# Altium schematic sheet REO_ECG.SchDoc
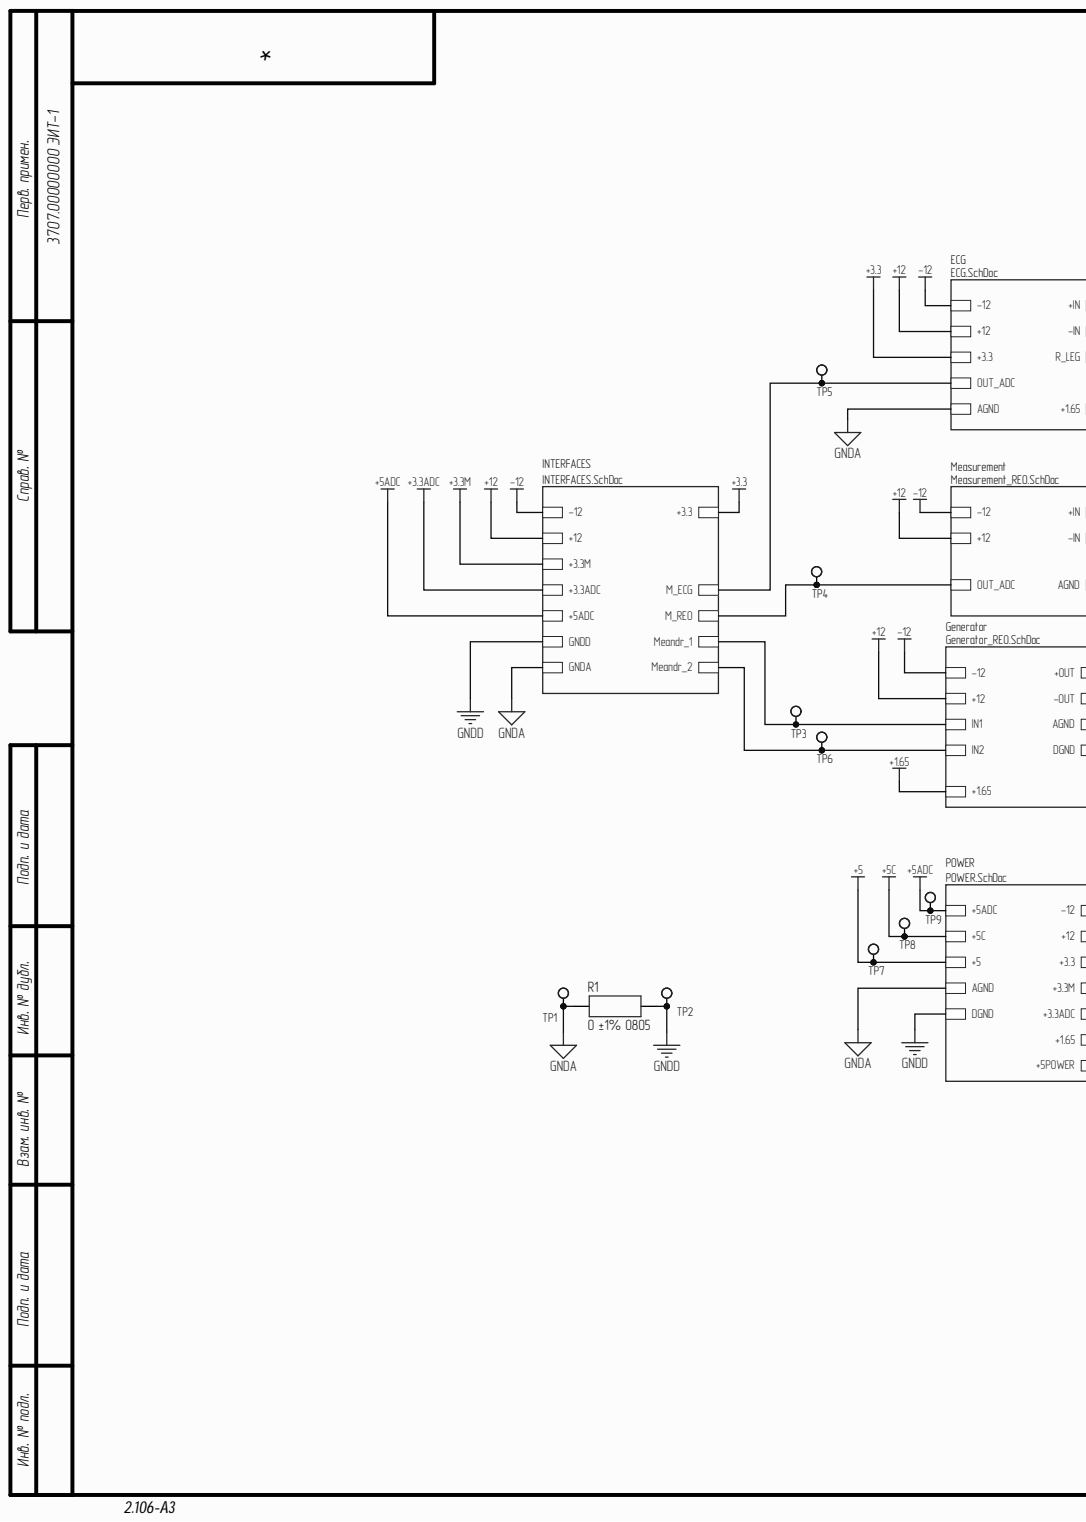
[diagram p0: REO_ECG.SchDoc, left]
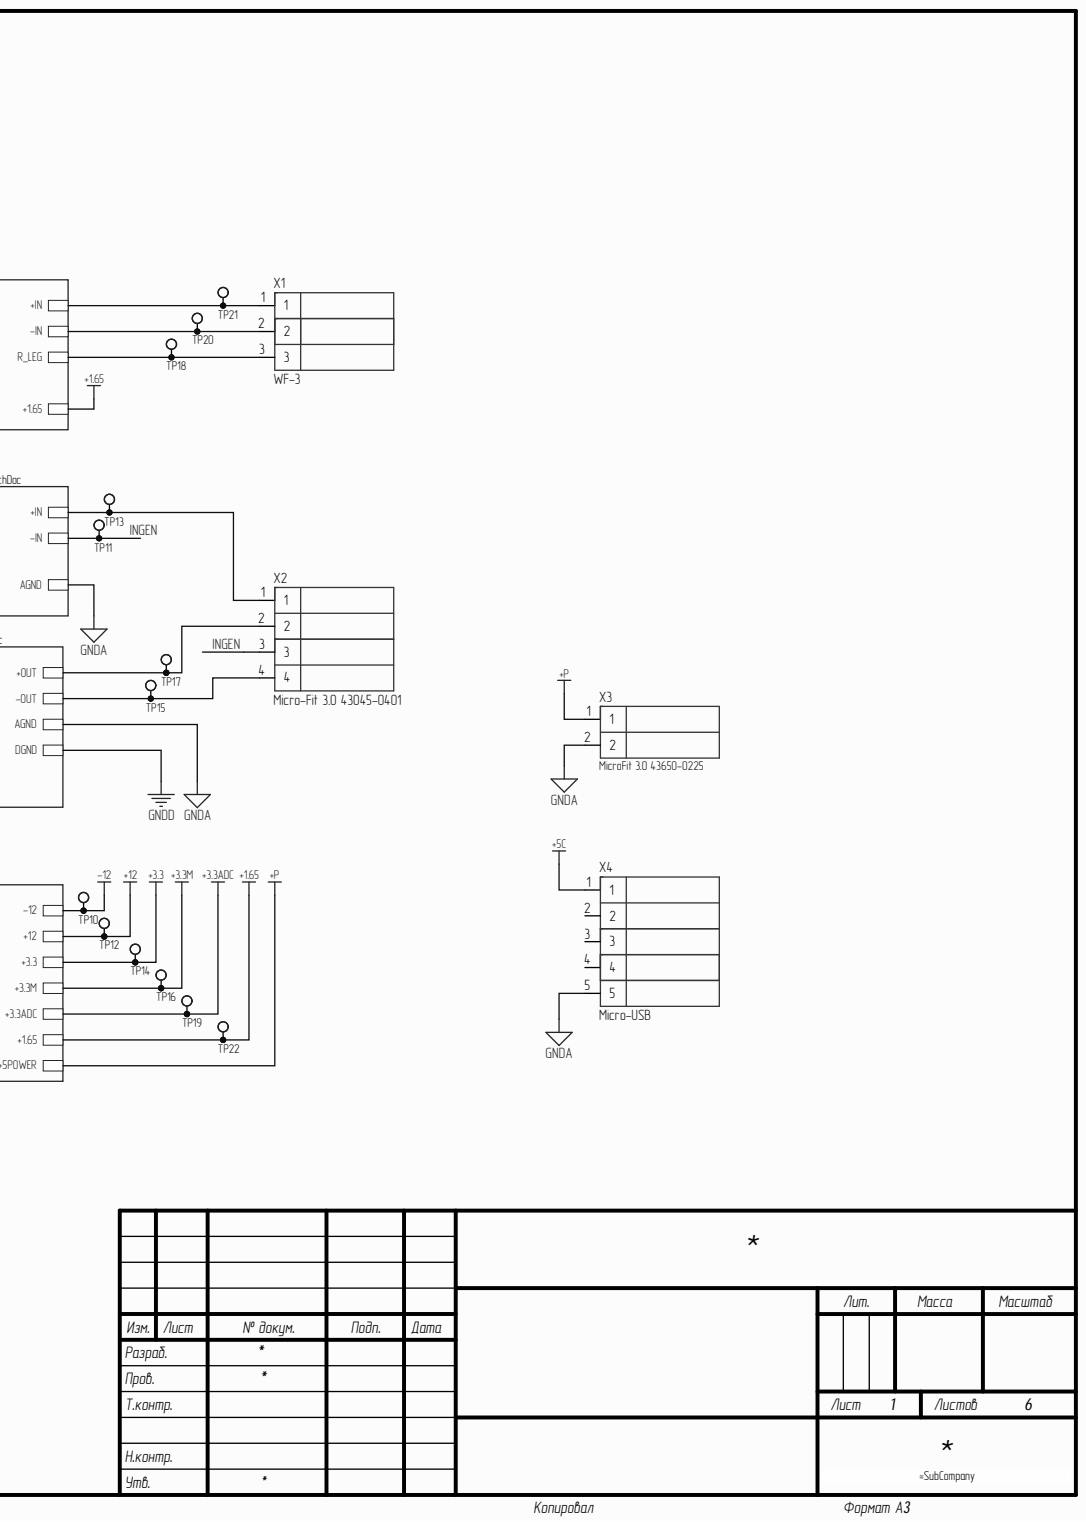
[diagram p1: REO_ECG.SchDoc, right]

## Parts
R1  0 ±1% 0805  [RES: SMD 0805 MD IPC 782]
TP1  Test point  [Test Point]  (value not drawn)  (net tie)
TP2  Test point  [Test Point]  (value not drawn)  (net tie)
TP3  Test point  [Test Point]  (value not drawn)  (net tie)
TP4  Test point  [Test Point]  (value not drawn)  (net tie)
TP5  Test point  [Test Point]  (value not drawn)  (net tie)
TP6  Test point  [Test Point]  (value not drawn)  (net tie)
TP7  Test point  [Test Point]  (value not drawn)  (net tie)
TP8  Test point  [Test Point]  (value not drawn)  (net tie)
TP9  Test point  [Test Point]  (value not drawn)  (net tie)
TP10  Test point  [Test Point]  (value not drawn)  (net tie)
TP11  Test point  [Test Point]  (value not drawn)  (net tie)
TP12  Test point  [Test Point]  (value not drawn)  (net tie)
TP13  Test point  [Test Point]  (value not drawn)  (net tie)
TP14  Test point  [Test Point]  (value not drawn)  (net tie)
TP15  Test point  [Test Point]  (value not drawn)  (net tie)
TP16  Test point  [Test Point]  (value not drawn)  (net tie)
TP17  Test point  [Test Point]  (value not drawn)  (net tie)
TP18  Test point  [Test Point]  (value not drawn)  (net tie)
TP19  Test point  [Test Point]  (value not drawn)  (net tie)
TP20  Test point  [Test Point]  (value not drawn)  (net tie)
TP21  Test point  [Test Point]  (value not drawn)  (net tie)
TP22  Test point  [Test Point]  (value not drawn)  (net tie)
X1  WF-3  [CON: 3pin wf-3]
X2  Micro-Fit 3.0 43045-0401  [CON: 4pin Molex MicroFit 3.0 43045-0401]
X3  MicroFit 3.0 43650-0225  [CON: 2pin Molex MicroFit 3.0 43650-0225]
X4  Micro-USB  [CON: 5pin USB Micro]

## Nets
+1.65: TP22.1
+3.3: TP14.1
+3.3ADC: TP19.1
+3.3M: TP16.1
+5: TP7.1
+5ADC: TP9.1
+5C: TP8.1 X4.1
+12: TP12.1
+P: X3.1
-12: TP10.1
GNDA: R1.1 TP1.1 X3.2 X4.5
GNDD: R1.2 TP2.1
INGEN: TP11.1 X2.3
NetTP3_1: TP3.1
NetTP4_1: TP4.1
NetTP5_1: TP5.1
NetTP6_1: TP6.1
NetTP13_1: TP13.1 X2.1
NetTP15_1: TP15.1 X2.4
NetTP17_1: TP17.1 X2.2
NetTP18_1: TP18.1 X1.3
NetTP20_1: TP20.1 X1.2
NetTP21_1: TP21.1 X1.1
NetX4_2: X4.2
NetX4_3: X4.3
NetX4_4: X4.4

## Library data of the parts
R1 = 0 ±1% 0805: Code: 6094342239; Name: Резистор 0R ±1% 0805; Component Kind: Standard; Library Name: UOMP_resistors.SchLib
X1 = 3 pin WF-3: Code: 6313932013; Name: Вилка WF-3; Component Kind: Standard; Library Name: UOMP connectors.SchLib
X2 = 4 pin MicroFit DR R Molex: Component Kind: Standard; Library Name: UOMP_connectors.SchLib
X3 = 2 pin MicroFit SR Molex: Code: 6319211711; Name: Вилка Micro-Fit 3.0 43650-0225, Molex; Component Kind: Standard; Library Name: UOMP_connectors.SchLib
X4 = 5 pin USB Micro SMD: Code: 6319209171; Name: Розетка 10118194-0001LF, Amphenol; Component Kind: Standard; Library Name: UOMP_connectors.SchLib
TP1-TP22 = Test point: Library Name: UOMP_primitives.SchLib
